# Altium schematic sheet Sch-QWA59_WiFi_BT_ON_OFF.SchDoc
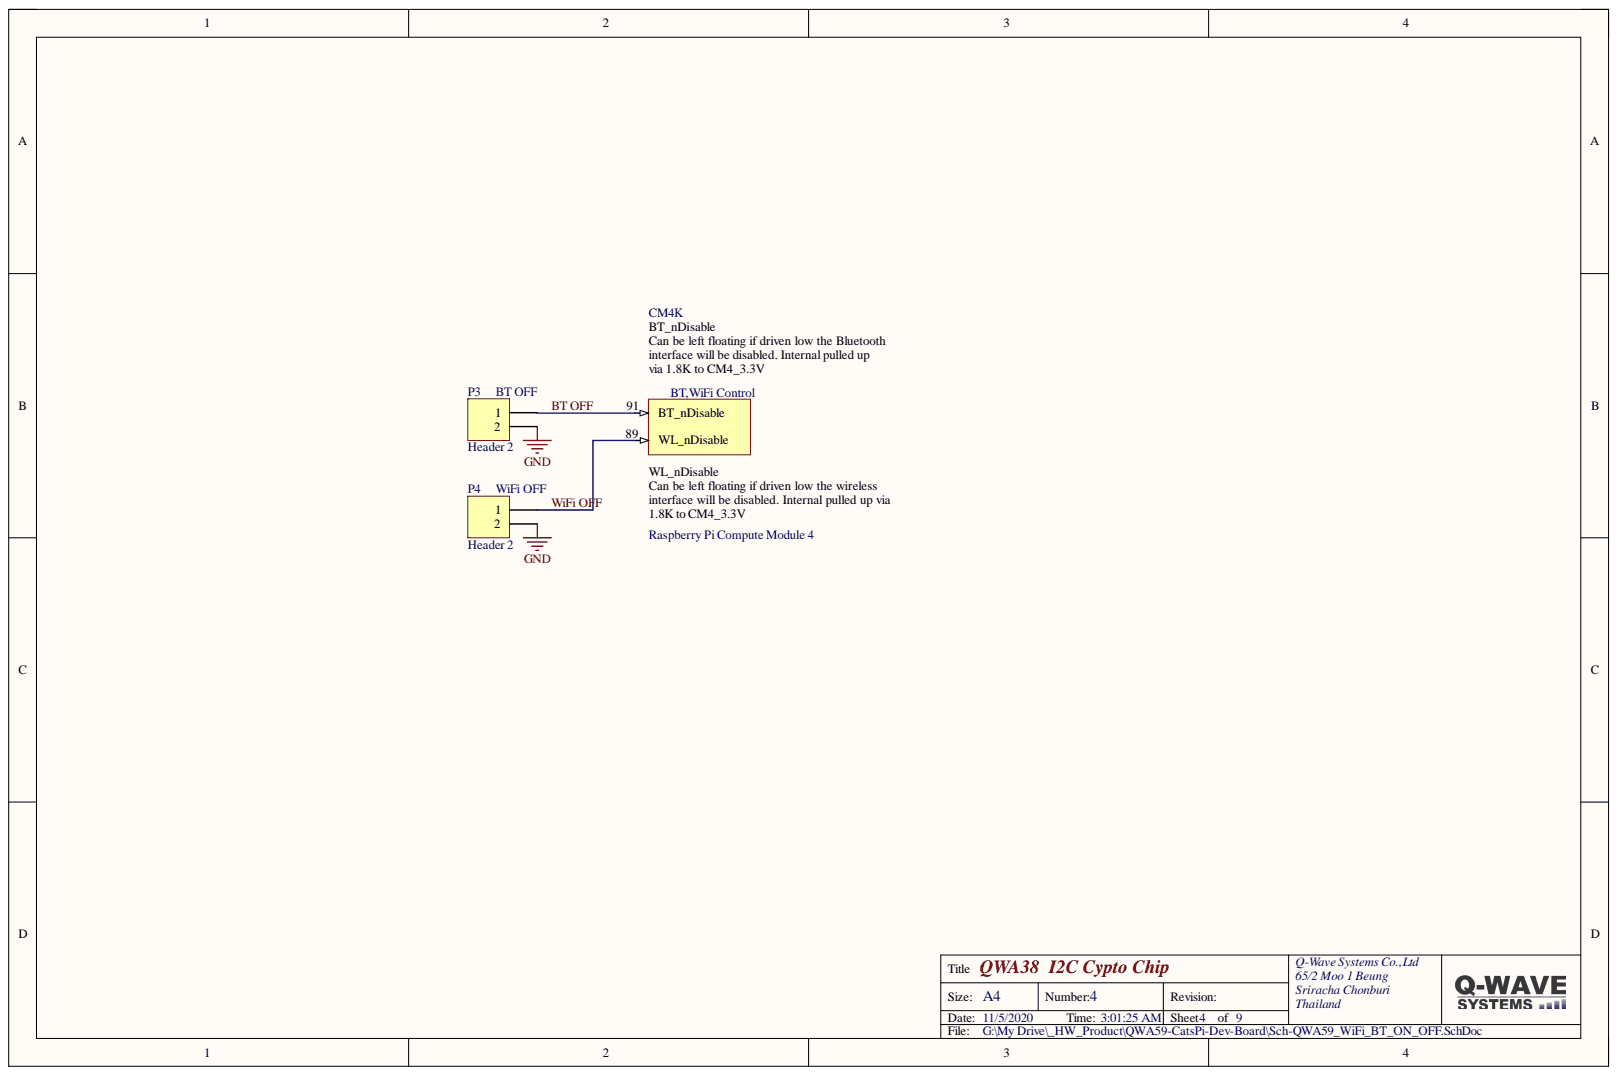
[diagram p0: Sch-QWA59_WiFi_BT_ON_OFF.SchDoc]

## Parts
CM4  Raspberry Pi Compute Module 4  [CM4-F40C-100DS-0.4v_1.5mm_0mm]  (part K)
P3  Header 2  [HDR1X2]
P4  Header 2  [HDR1X2]

## Nets
GND: P3.2 P4.2
BT OFF: CM4.91(BT_nDisable) P3.1
WiFi OFF: CM4.89(WL_nDisable) P4.1

## Library data of the parts
CM4 = CM4: Raspberry Pi Compute Module 4 by Amornthep Phunsin; Component Kind: Standard; Library Name: CM4.SchLib
P3 P4 = Header 2: Header, 2-Pin; Component Kind: Standard; LatestRevisionDate: 17-Jul-2002; LatestRevisionNote: Re-released for DXP Platform.; Library Name: Miscellaneous Connectors.IntLib; Signal Integrity: Connector; Publisher: Altium Limited
